# Altium schematic sheet [06] USB_C.SchDoc("[06] USB_C")
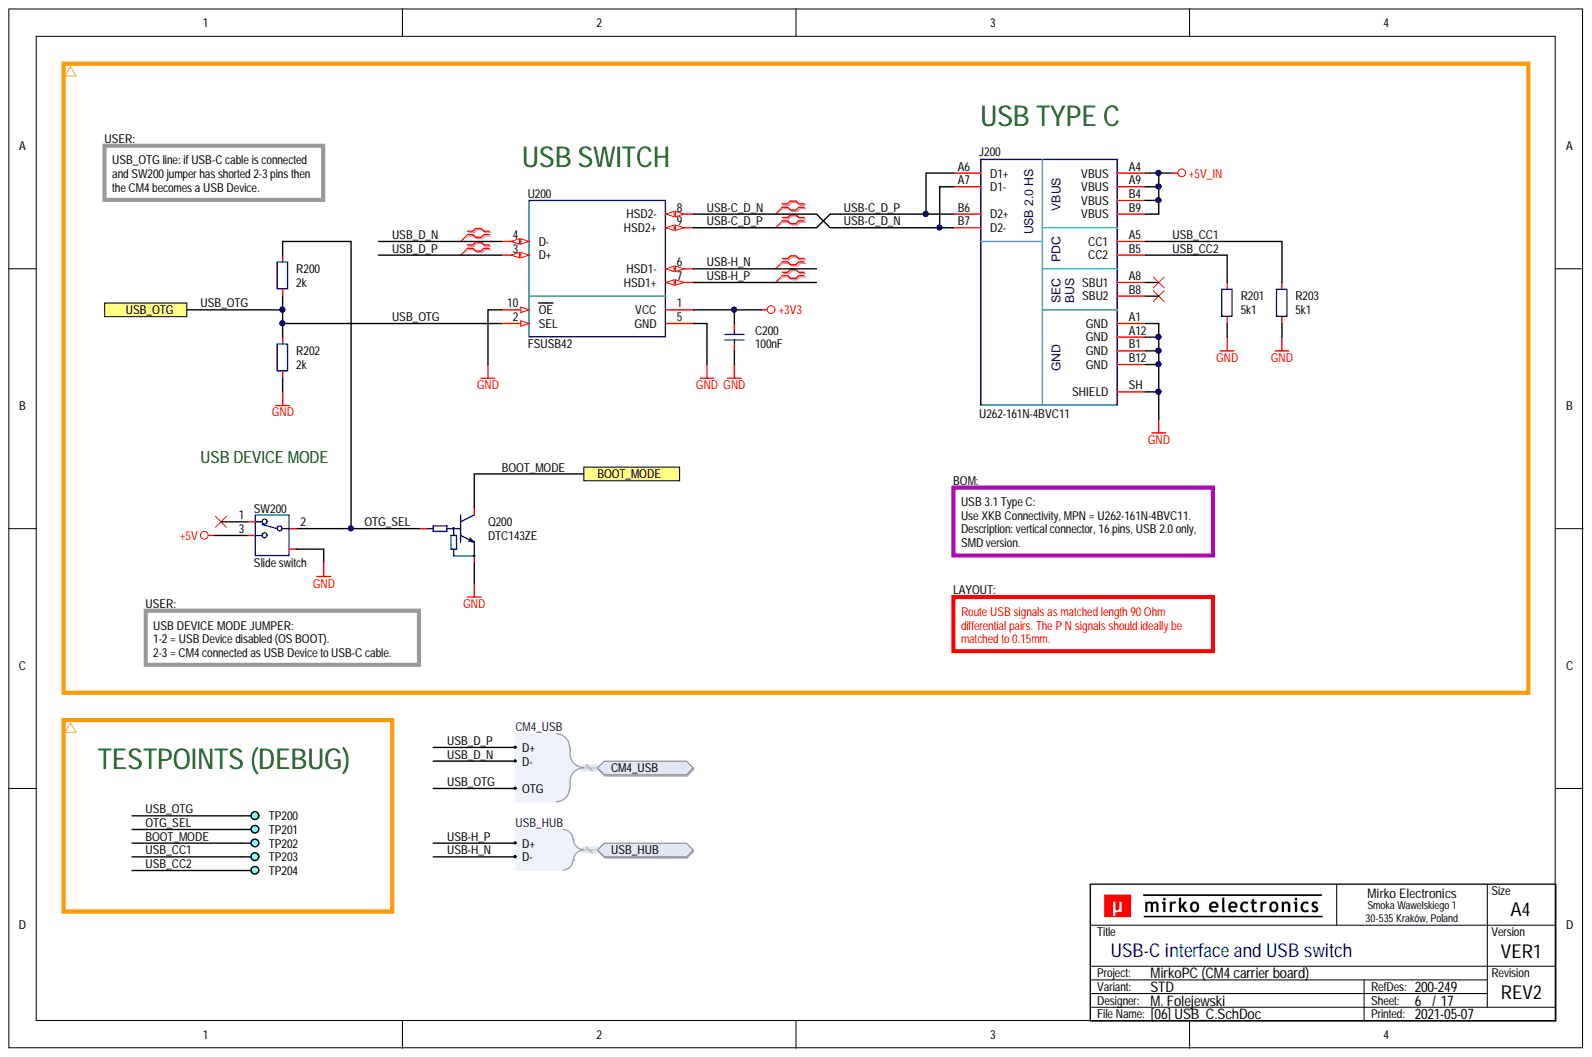
[diagram p0: [06] USB_C.SchDoc]

## Parts
C200  100nF  [CAP_0603_R]
J200  U262-161N-4BVC11  [CON_XKB_U262-161N-4BVC11]
Q200  DTC143ZE  [PKG_SOT523_SC75_SOT416_P100_R]
R200  2k  [RES_0603_R]
R201  5k1  [RES_0603_R]
R202  2k  [RES_0603_R]
R203  5k1  [RES_0603_R]
SW200  Slide switch  Component Subtype: Slide  [SWI_XKB_SK-3296S-01-L3]
TP200  DEBUG  [PCB_TP_DBG_50]  (value not drawn)  (no BOM)
TP201  DEBUG  [PCB_TP_DBG_50]  (value not drawn)  (no BOM)
TP202  DEBUG  [PCB_TP_DBG_50]  (value not drawn)  (no BOM)
TP203  DEBUG  [PCB_TP_DBG_50]  (value not drawn)  (no BOM)
TP204  DEBUG  [PCB_TP_DBG_50]  (value not drawn)  (no BOM)
U200  FSUSB42  [ICC_MSOP10_300X300H110_P50_R]

## Ports
BOOT_MODE, CM4_USB, CM4_USB.D+, CM4_USB.D-, CM4_USB.OTG, USB_HUB, USB_HUB.D+, USB_HUB.D-, USB_OTG

## Nets
+3V3: C200.2 U200.1(VCC)
+5V: SW200.3
+5V_IN: J200.A4(VBUS) J200.A9(VBUS) J200.B4(VBUS) J200.B9(VBUS)
GND: C200.1 J200.A1(GND) J200.A12(GND) J200.B1(GND) J200.B12(GND) J200.SH(SHIELD) Q200.2 R201.2 R202.1 R203.2 SW200.SH U200.5(GND) U200.10(OE)
BOOT_MODE: Q200.3 TP202.1 ; port BOOT_MODE
OTG_SEL: Q200.1 R200.2 SW200.2 TP201.1
USB-C_D_N: J200.A7(D1-) J200.B7(D2-) U200.8(HSD2-)
USB-C_D_P: J200.A6(D1+) J200.B6(D2+) U200.9(HSD2+)
USB-H_N: U200.6(HSD1-) ; port USB_HUB
USB-H_P: U200.7(HSD1+) ; port USB_HUB
USB_CC1: J200.A5(CC1) R203.1 TP203.1
USB_CC2: J200.B5(CC2) R201.1 TP204.1
USB_D_N: U200.4(D-) ; port CM4_USB
USB_D_P: U200.3(D+) ; port CM4_USB
USB_OTG: R200.1 R202.2 TP200.1 U200.2(SEL) ; port CM4_USB, port USB_OTG

Not connected: J200 A8(SBU1) B8(SBU2); SW200 1

## Library data of the parts
C200 = CAP-0008: Capacitor; MLCC; 100nF; TBD; TBD; TBD; TBD; SMD; 0603 (1608);; Database Table Name: CAPACITOR$; Value: 100nF; Supplier: ?; Package (Case): 0603 (1608); MPN: TBD; Mounting Type: SMD; Manufacturer: TBD; Component Type: Capacitor; Component Subtype: MLCC; Component Kind: Standard; Library Name: MirFol_db_local.DbLib
J200 = CON_USB_TYPE_C_16P: Connector; USB 3.1 Type C; Receptacle; 24-pin; USB 2.0 Only;; Manufacturer: XKB Connectivity; Package (Case): SMD; Component Subtype: USB-C; Value: U262-161N-4BVC11; Supplier: ?; MPN: U262-161N-4BVC11; Mounting Type: SMD; Component Type: Connector; Component Kind: Standard; Library Name: MirFol_lib.SchLib
Q200 = TRA_BJT_NPN_DIG_BEC: Transistor; BJT; NPN; Digital;; Component Subtype: NPN Transistor; Value: DTC143ZE; Supplier: ?; Mounting Type: SMD; Component Type: Transistor; Component Kind: Standard; Library Name: MirFol_lib.SchLib
R200 R202 = RES-0033: Resistor; Thick Film; 2k; 1%; 100mW; 100PPM; 75V; Standard; SMD; 0603 (1608);; MPN: TBD; Manufacturer: Yageo Corporation; Database Table Name: RESISTOR$; Value: 2k; Supplier: ?; Package (Case): 0603 (1608); Mounting Type: SMD; Component Type: Resistor; Component Subtype: Thick Film; Component Kind: Standard; Library Name: MirFol_db_local.DbLib
R201 R203 = RES-0043: Resistor; Thick Film; 5k1; 1%; 100mW; 100PPM; 75V; Standard; SMD; 0603 (1608);; MPN: TBD; Manufacturer: Yageo Corporation; Database Table Name: RESISTOR$; Value: 5k1; Supplier: ?; Package (Case): 0603 (1608); Mounting Type: SMD; Component Type: Resistor; Component Subtype: Thick Film; Component Kind: Standard; Library Name: MirFol_db_local.DbLib
SW200 = SWI_SPDT_3P_SH1: Switch; SPDT;; MPN: SK-3296S-01-L3; Manufacturer: XKB Connectivity; Package (Case): SMD; Value: Slide switch; Supplier: LCSC; Mounting Type: SMD; Component Type: Switch; Component Kind: Standard; Library Name: MirFol_lib.SchLib
TP200-TP204 = PCB_TP_DBG: PCB Marking; Test Point (Debug);; Value: DEBUG; Supplier: ?; Mounting Type: -; Component Type: Testpoint; Component Subtype: -; Library Name: MirFol_lib.SchLib
U200 = ICC-0013: IC; USB Switch; TBD TBD; SMD; MSOP-10;; MPN: FSUSB42MUX; Manufacturer: ON Semiconductor; Database Table Name: IC (MISC)$; Value: FSUSB42; Supplier: ?; Package (Case): MSOP-10; Mounting Type: SMD; Component Type: IC; Component Subtype: USB Switch; Component Kind: Standard; Library Name: MirFol_db_local.DbLib
